# Altium schematic sheet Controller_PCB_LED.SchDoc
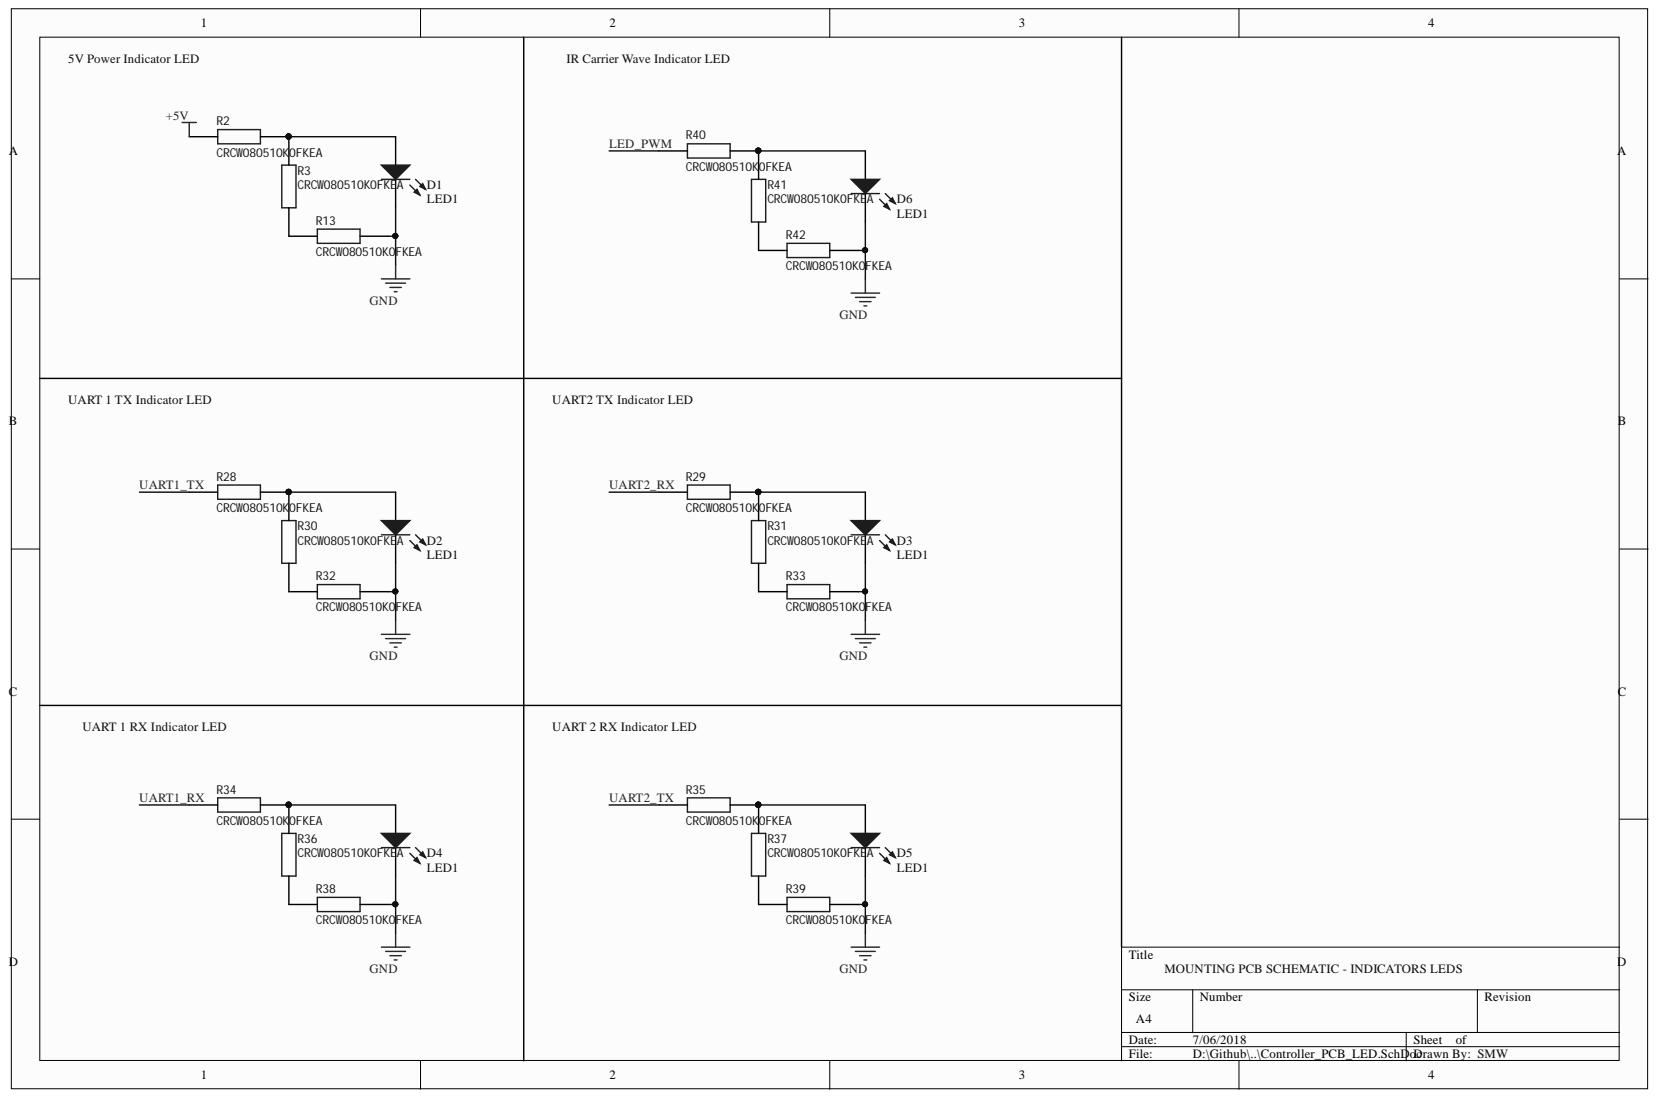
[diagram p0: Controller_PCB_LED.SchDoc]

## Parts
D1  LED1  [L0603]
D2  LED1  [L0603]
D3  LED1  [L0603]
D4  LED1  [L0603]
D5  LED1  [L0603]
D6  LED1  [L0603]
R2  CRCW080510K0FKEA  [RESC2012X50N]
R3  CRCW080510K0FKEA  [RESC2012X50N]
R13  CRCW080510K0FKEA  [RESC2012X50N]
R28  CRCW080510K0FKEA  [RESC2012X50N]
R29  CRCW080510K0FKEA  [RESC2012X50N]
R30  CRCW080510K0FKEA  [RESC2012X50N]
R31  CRCW080510K0FKEA  [RESC2012X50N]
R32  CRCW080510K0FKEA  [RESC2012X50N]
R33  CRCW080510K0FKEA  [RESC2012X50N]
R34  CRCW080510K0FKEA  [RESC2012X50N]
R35  CRCW080510K0FKEA  [RESC2012X50N]
R36  CRCW080510K0FKEA  [RESC2012X50N]
R37  CRCW080510K0FKEA  [RESC2012X50N]
R38  CRCW080510K0FKEA  [RESC2012X50N]
R39  CRCW080510K0FKEA  [RESC2012X50N]
R40  CRCW080510K0FKEA  [RESC2012X50N]
R41  CRCW080510K0FKEA  [RESC2012X50N]
R42  CRCW080510K0FKEA  [RESC2012X50N]

## Nets
+5V: R2.1
GND: D1.2 D2.2 D3.2 D4.2 D5.2 D6.2 R13.1 R32.1 R33.1 R38.1 R39.1 R42.1
LED_PWM: R40.1
UART1_RX: R34.1
UART1_TX: R28.1
UART2_RX: R29.1
UART2_TX: R35.1
NetD1_1: D1.1 R2.2 R3.2
NetD2_1: D2.1 R28.2 R30.2
NetD3_1: D3.1 R29.2 R31.2
NetD4_1: D4.1 R34.2 R36.2
NetD5_1: D5.1 R35.2 R37.2
NetD6_1: D6.1 R40.2 R41.2
NetR3_1: R3.1 R13.2
NetR30_1: R30.1 R32.2
NetR31_1: R31.1 R33.2
NetR36_1: R36.1 R38.2
NetR37_1: R37.1 R39.2
NetR41_1: R41.1 R42.2

## Library data of the parts
D1-D6 = LED1: Typical RED GaAs LED; Component Kind: Standard; LatestRevisionDate: 08-Jul-2005; LatestRevisionNote: Mech Layer 1 removed.; Library Name: Miscellaneous Devices.IntLib; PackageDescription: LED; 2 Leads; PackageReference: LED-1; Published: 8-Jun-2000; Simulation: LED1; Publisher: Altium Limited
R2 R3 R13 R28-R42 = CRCW080510K0FKEA: CRCW0805 Resistor T/R 0.125W,1%,10K Vishay CRCW Series Thick Film Surface Mount Resistor 0805 Case 10k 1% 0.125W 100ppm/C; Allied_Number: 70203987; Geometry.Height: 0.5mm; Component Kind: Standard; Datasheet Link: http://uk.rs-online.com/web/p/products/1694499; Library Name: CRCW080510K0FKEA.SchLib; Manufacturer_Name: Vishay; Manufacturer_Part_Number: CRCW080510K0FKEA; RS Part Number: 1694499
